# Altium schematic sheet INA.SchDoc(INA)
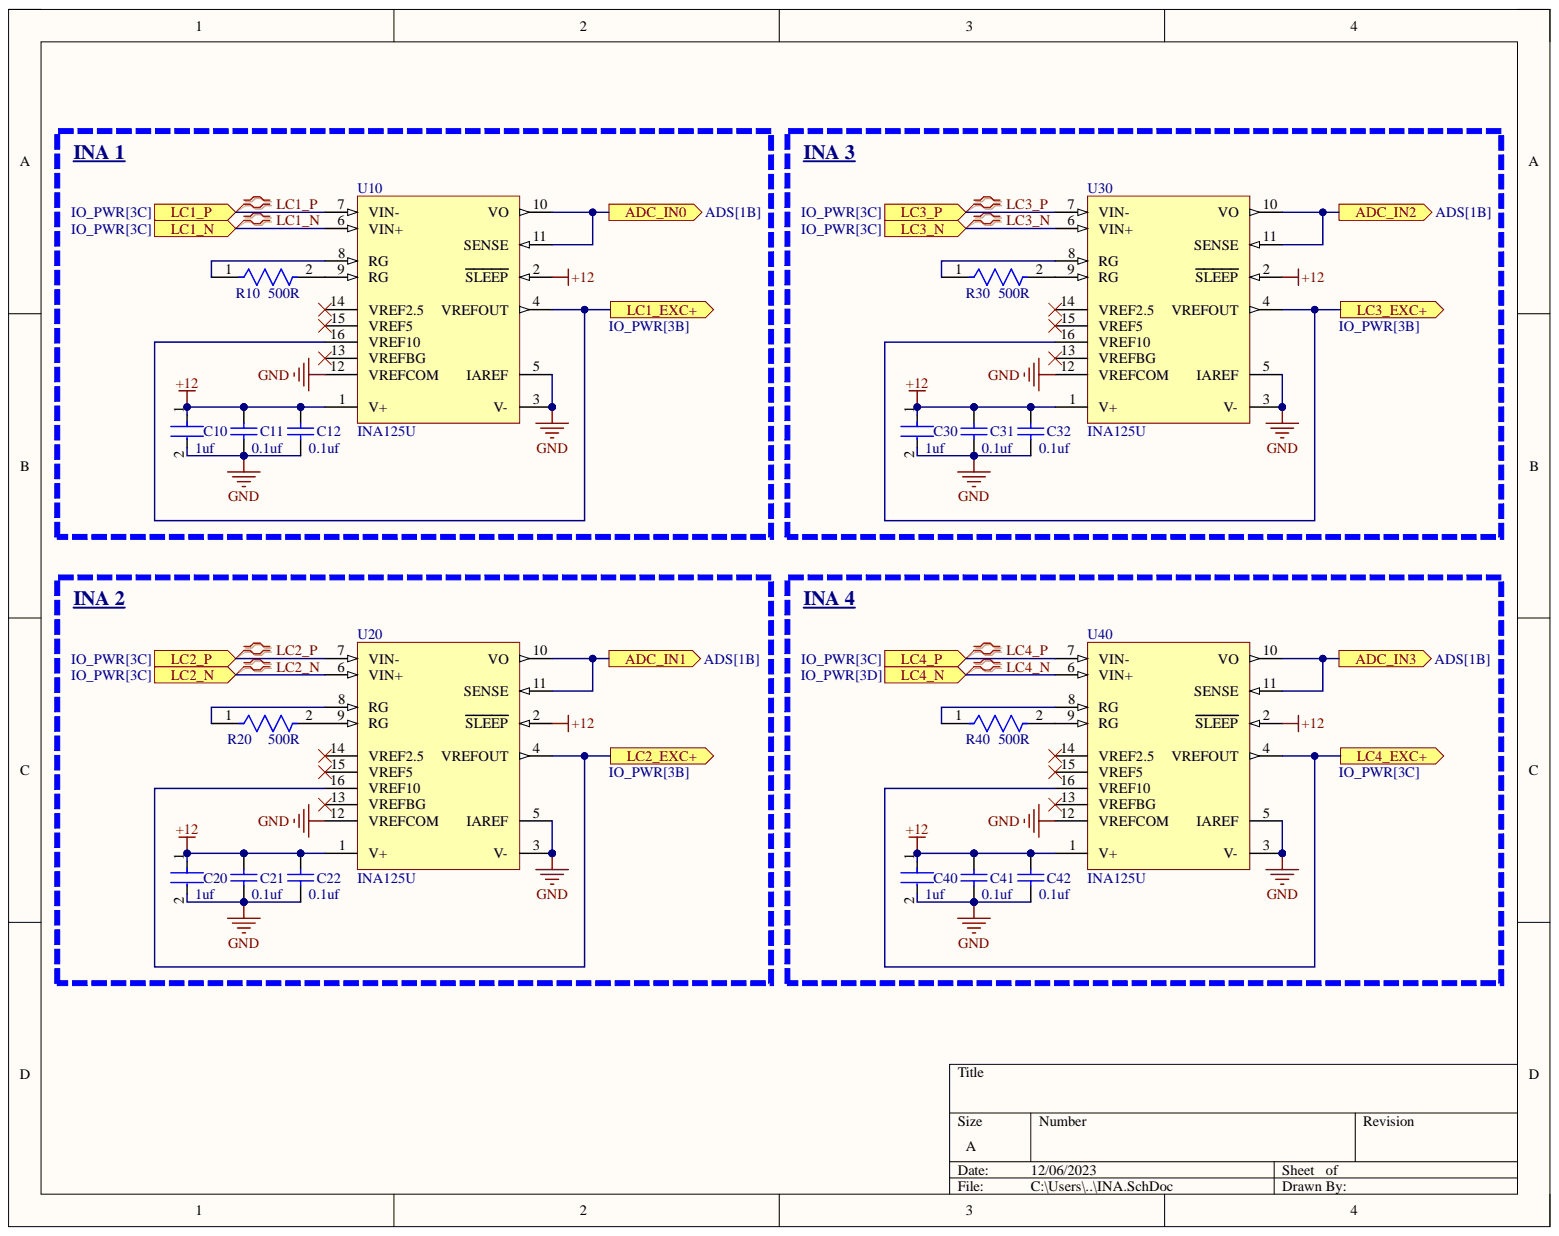
[diagram p0: INA.SchDoc]

## Parts
C10  1uf  [FP-1206-L_3_2_0_2-W_1_6_0-IPC_A]
C11  0.1uf  [CAPC1005X56X25NL10T15]
C12  0.1uf  [CAPC1005X56X25NL10T15]
C20  1uf  [FP-1206-L_3_2_0_2-W_1_6_0-IPC_A]
C21  0.1uf  [CAPC1005X56X25NL10T15]
C22  0.1uf  [CAPC1005X56X25NL10T15]
C30  1uf  [FP-1206-L_3_2_0_2-W_1_6_0-IPC_A]
C31  0.1uf  [CAPC1005X56X25NL10T15]
C32  0.1uf  [CAPC1005X56X25NL10T15]
C40  1uf  [FP-1206-L_3_2_0_2-W_1_6_0-IPC_A]
C41  0.1uf  [CAPC1005X56X25NL10T15]
C42  0.1uf  [CAPC1005X56X25NL10T15]
R10  500R  [FP-TFCR0603-IPC_A]
R20  500R  [FP-TFCR0603-IPC_A]
R30  500R  [FP-TFCR0603-IPC_A]
R40  500R  [FP-TFCR0603-IPC_A]
U10  INA125U  [D0016A_N]
U20  INA125U  [D0016A_N]
U30  INA125U  [D0016A_N]
U40  INA125U  [D0016A_N]

## Ports
ADC_IN0, ADC_IN1, ADC_IN2, ADC_IN3, LC1_EXC+, LC1_N, LC1_P, LC2_EXC+, LC2_N, LC2_P, LC3_EXC+, LC3_N, LC3_P, LC4_EXC+, LC4_N, LC4_P

## Nets
+12: C10.1 C11.2 C12.2 C20.1 C21.2 C22.2 C30.1 C31.2 C32.2 C40.1 C41.2 C42.2 U10.1(V+) U10.2(SLEEP) U20.1(V+) U20.2(SLEEP) U30.1(V+) U30.2(SLEEP) U40.1(V+) U40.2(SLEEP)
GND: C10.2 C11.1 C12.1 C20.2 C21.1 C22.1 C30.2 C31.1 C32.1 C40.2 C41.1 C42.1 U10.3(V-) U10.5(IAREF) U10.12(VREFCOM) U20.3(V-) U20.5(IAREF) U20.12(VREFCOM) U30.3(V-) U30.5(IAREF) U30.12(VREFCOM) U40.3(V-) U40.5(IAREF) U40.12(VREFCOM)
ADC_IN0: U10.10(VO) U10.11(SENSE) ; port ADC_IN0
ADC_IN1: U20.10(VO) U20.11(SENSE) ; port ADC_IN1
ADC_IN2: U30.10(VO) U30.11(SENSE) ; port ADC_IN2
ADC_IN3: U40.10(VO) U40.11(SENSE) ; port ADC_IN3
LC1_EXC+: U10.4(VREFOUT) U10.16(VREF10) ; port LC1_EXC+
LC1_N: U10.6(VIN+) ; port LC1_N
LC1_P: U10.7(VIN-) ; port LC1_P
LC2_EXC+: U20.4(VREFOUT) U20.16(VREF10) ; port LC2_EXC+
LC2_N: U20.6(VIN+) ; port LC2_N
LC2_P: U20.7(VIN-) ; port LC2_P
LC3_EXC+: U30.4(VREFOUT) U30.16(VREF10) ; port LC3_EXC+
LC3_N: U30.6(VIN+) ; port LC3_N
LC3_P: U30.7(VIN-) ; port LC3_P
LC4_EXC+: U40.4(VREFOUT) U40.16(VREF10) ; port LC4_EXC+
LC4_N: U40.6(VIN+) ; port LC4_N
LC4_P: U40.7(VIN-) ; port LC4_P
NetR10_1: R10.1 U10.8(RG)
NetR10_2: R10.2 U10.9(RG)
NetR20_1: R20.1 U20.8(RG)
NetR20_2: R20.2 U20.9(RG)
NetR30_1: R30.1 U30.8(RG)
NetR30_2: R30.2 U30.9(RG)
NetR40_1: R40.1 U40.8(RG)
NetR40_2: R40.2 U40.9(RG)

Not connected: U10 13(VREFBG) 14(VREF2.5) 15(VREF5); U20 13(VREFBG) 14(VREF2.5) 15(VREF5); U30 13(VREFBG) 14(VREF2.5) 15(VREF5); U40 13(VREFBG) 14(VREF2.5) 15(VREF5)

## Library data of the parts
C10 C20 C30 C40 = CMP-04427-011252-1: Automotive Ceramic Capacitor, 1206, 1uF, 10%, X7R, 15%, 25V; Capacitance: 1uF; Case Code (Imperial): 1206; Case Code (Metric): 3216; Case/Package: 1206; Component Kind: Standard; Construction: Flat; Depth: 1.6mm; Dielectric: X7R; Features: Soft Termination; Height: 1.4mm; Length: 3.2mm; Library Name: Altium Content Vault; Max Operating Temperature: 125°C; Min Operating Temperature: -55°C; Mount: Surface Mount; Package Quantity: 2000; Packaging: Tape and Reel; Radiation Hardening: No; Ratings: AEC-Q200; RoHS Compliant: Yes; Series: FLEXITERM®; Termination: SMD/SMT; Thickness: 0.07inch; Tolerance: 10%; Voltage Rating: 25V; Voltage Rating (DC): 25V; Width: 1.6mm
C11 C12 C21 C22 C31 C32 C41 C42 = CMP-1034-02072-2: Manufacturer: AVX Interconnect / Elco; Part Number: 04023D104KAT2A; Component Kind: Standard; Library Name: Altium Content Vault
R10 R20 R30 R40 = CMP-250906-284725-1: RES Thin Film,  500Ω, 0.1%, 0.1W, 25ppm/°C, 0603; Component Kind: Standard; Library Name: Altium Content Vault; RoHS Compliant: Yes
U10 U20 U30 U40 = CMP-0944-00023-2: Instrumentation Amplifier with Precision Voltage Reference, -40 to 85 degC, 16-pin SOIC (D16), Green (RoHS & no Sb/Br); Manufacturer: Texas Instruments; CMRR(Min)(dB): 100; Gain(V/V): 4 to 10000; Input Bias Current (+/-)(Max)(nA): 25; Input Offset (+/-)(Max)(uV): 250; Input Offset Drift (+/-)(Max)(uV/Degrees Celsius): 2; Noise at 1kHz(Typ)(nV/rt(Hz)): 38; Non-Linearity (+/-)(Max)(%): 0.01; Number of Channels: 1; Quiescent Current (+/-)(Typ)(mA): 0.46; SubFamily: Single Supply; Vs(Max)(V): 36; Vs(Min)(V): 2.7; Code_JEDEC: MS-012; Component Kind: Standard; ComponentLink1Description: Manufacturer URL; ComponentLink1URL: http://www.ti.com/; ComponentLink2Description: Datasheet; ComponentLink2URL: http://www.ti.com/general/docs/lit/getliterature.tsp?genericPartNumber=INA125&fileType=pdf; ComponentLink3Description: Package Specification; ComponentLink3URL: http://www.ti.com/lit/ml/mpds178g/mpds178g.pdf; DatasheetVersion: SBOS060; Eco-Plan: Green (RoHS & no Sb/Br); Library Name: Altium Content Vault; Mounting Technology: Surface Mount; PackageDescription: 16-Pin Plastic Small Outline, Body 9.9 x 3.9 mm, Pitch 1.27 mm; PackageReference: D0016A; PackageVersion: 4040047-6/M, 06/2011; PartNumber: INA125U
